# Altium schematic sheet Microphone-Boards_V1.0_GMA3526H10.SchDoc
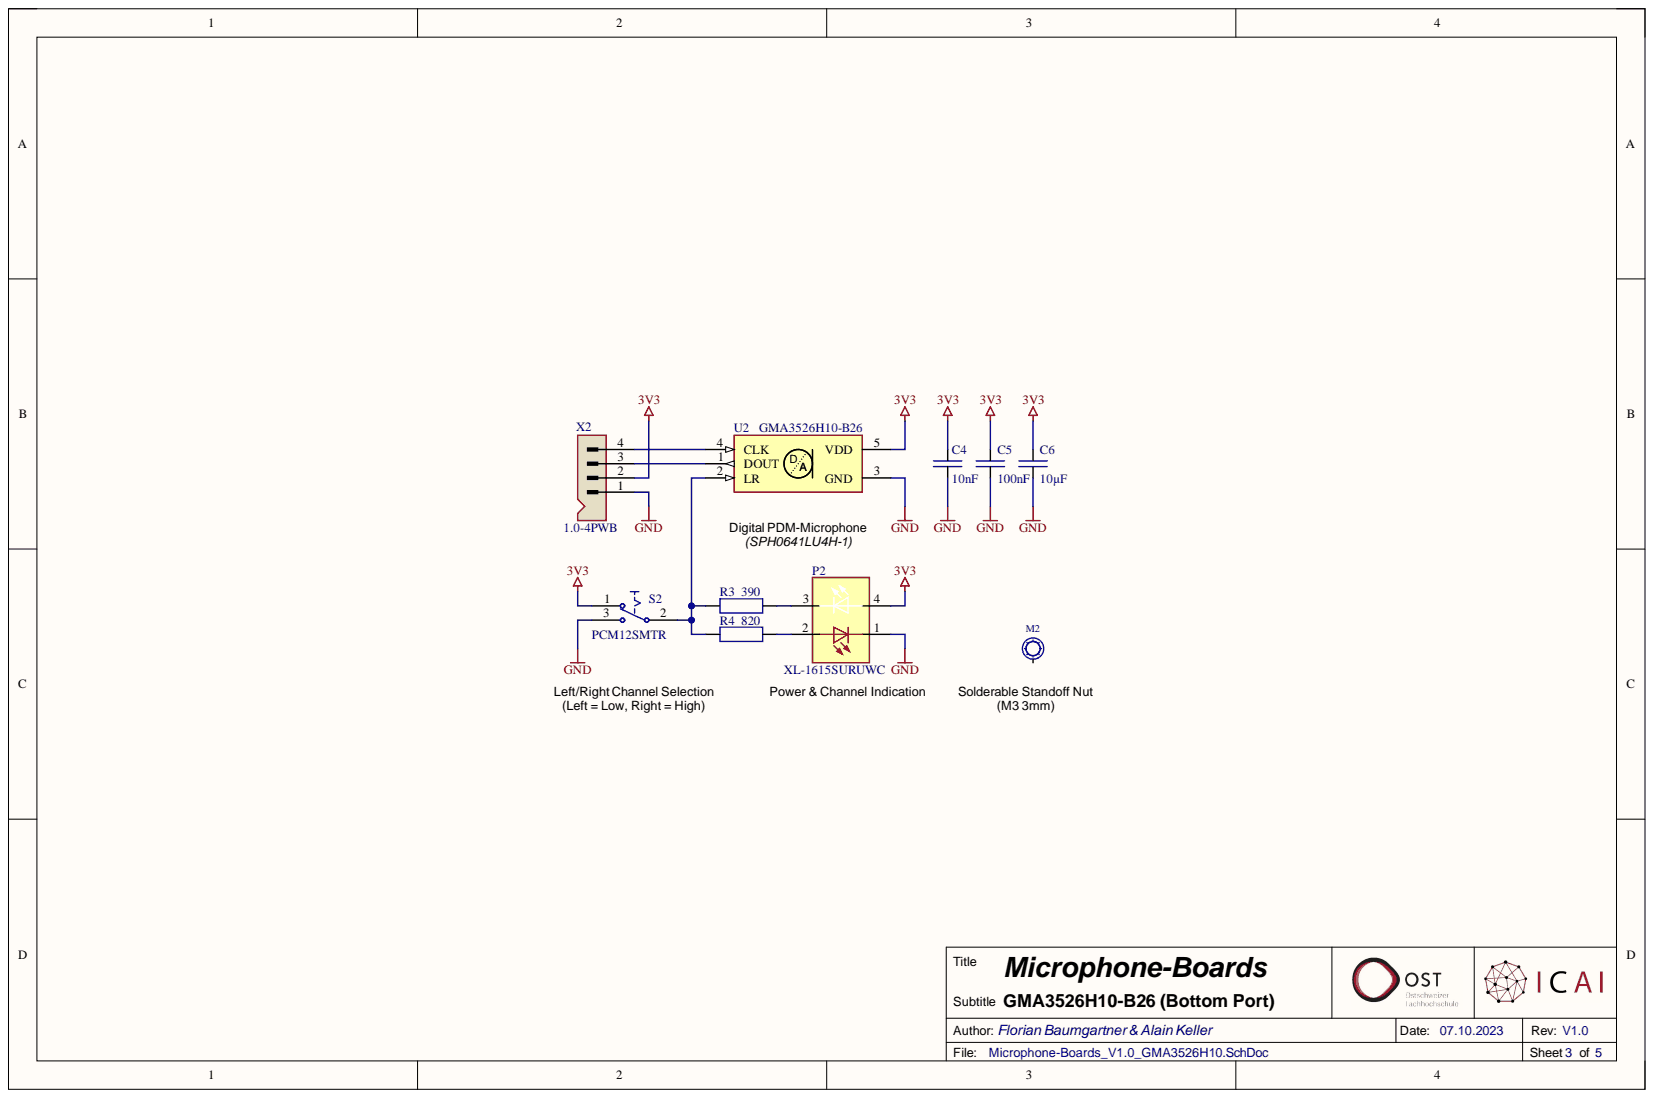
[diagram p0: Microphone-Boards_V1.0_GMA3526H10.SchDoc]

## Parts
C4  10nF  [SMD Keramikkondensator 0603]
C5  100nF  [SMD Keramikkondensator 0603]
C6  10µF  [SMD Keramikkondensator 1206]
M2  SMTSO-M3-3ET  [SMD Solder-Nut (M3 3mm)]  (value not drawn)
P2  XL-1615SURUWC  [SMD LED 1210]
R3  390  [SMD Widerstand 0603]
R4  820  [SMD Widerstand 0603]
S2  PCM12SMTR  [SMD Switch SPDT (PCM12SMTR)]
U2  GMA3526H10-B26  [SMD Microphone (MP34DT06JTR)]
X2  1.0-4PWB  [SMD Connector (SM04B-SRSS-TB)]

## Nets
3V3: C4.2 C5.2 C6.2 P2.4 S2.1 U2.5(VDD) X2.2
GND: C4.1 C5.1 C6.1 P2.1 S2.3 U2.3(GND) X2.1
NetP2_2: P2.2 R4.2
NetP2_3: P2.3 R3.2
NetR3_1: R3.1 R4.1 S2.2 U2.2(LR)
NetU2_1: U2.1(DOUT) X2.3
NetU2_4: U2.4(CLK) X2.4

Not connected: M2 1

## Library data of the parts
C4 = C-CAPACITOR: CAP-MK; Component Description: Multilayer Ceramic Capacitors; Component Value: 10nF 50V; Component Kind: Standard; Digikey: 445-5662-1-ND; Farnell: 2346966; JLC-Parts: C57112; Library Name: AudioAmp.SCHLIB; Mouser: 77-VJ0603V103ZXAPBC; Unit Price: 0.01
C5 = E6 - 100nF: SMD Ceramic Capacitor E6; Component Description: Multilayer Ceramic Capacitors MLCC; Component Value: 100nF 50V; Component Kind: Standard; ComponentLink1Description: Datasheet; ComponentLink1URL: https://datasheet.lcsc.com/lcsc/1809301912_YAGEO-CC0603KRX7R9BB104_C14663.pdf; Digikey: 445-6899-1-ND; Farnell: 2210822; JLC-Parts: C14663; Library Name: Ceramic Capacitors-E6.SchLib; Mouser: 810-CGA2B3X7R1H104K; Unit Price: 0.01
C6 = E6 - 10uF: SMD Ceramic Capacitor E6; Component Description: Multilayer Ceramic Capacitors MLCC; Component Value: 10µF 50V; Component Kind: Standard; ComponentLink1Description: Datasheet; ComponentLink1URL: https://datasheet.lcsc.com/lcsc/1810191219_Samsung-Electro-Mechanics-CL10A106KP8NNNC_C19702.pdf; Digikey: 587-3258-1-ND; Farnell: 2113070; JLC-Parts: C13585; Library Name: Ceramic Capacitors-E6.SchLib; Mouser: 963-LMK107BBJ106MALT; Unit Price: 0.01
M2 = M-Spacer_M3_3mm: Solderable Standoff Nut; Component Value: M3 3mm; Component Description: Solderable Standoff Nut; Component Kind: Standard; ComponentLink1Description: Datasheet; ComponentLink1URL: https://datasheet.lcsc.com/lcsc/2112061830_Sinhoo-SMTSO3030CTJ_C2915308.pdf; JLC-Parts: C2915308; Mouser: 153-SMTSO-M3-3ET; Unit Price: 0.05; Library Name: Velotour_2022.SCHLIB
P2 = P-XL-1615SURUWC: LED Red/White; Stückpreis: 0.02; Bauteilwert: XL-1615SURUWC; Beschreibung: LED Red/White; Component Kind: Standard; ComponentLink1Description: Datasheet; ComponentLink1URL: https://datasheet.lcsc.com/lcsc/2211030000_XINGLIGHT-XL-1615SURUWC_C965837.pdf; JLC-Parts: C965837; Library Name: Microphone-Boards.SCHLIB
R3 = R-RESISTOR: SMD-Widerstand 1/4W; Component Description: Thick Film Chip Resistor; Component Value: 390 (75V, 100mW, 1%); Component Kind: Standard; Digikey: P390HCT-ND; Farnell: 2303111; JLC-Parts: C23151; Mouser: 667-ERJ-3EKF3900V; Unit Price: 0.06; Library Name: Backplane_1.SCHLIB
R4 = R-RESISTOR: SMD-Widerstand 1/4W; Component Description: Thick Film Chip Resistor; Component Value: 820 (75V, 100mW, 1%); Component Kind: Standard; Digikey: P820HCT-ND; Farnell: 2303136; JLC-Parts: C23253; Mouser: 667-ERJ-3EKF8200V; Unit Price: 0.06; Library Name: Backplane_1.SCHLIB
S2 = S-PCM12SMTR: Miniature Slide Switch; aliExpress: 33002042977; Bauteilwert: PCM12SMTR (SPDT); Stückpreis: 0.77; Beschreibung: Miniature Slide Switch; Component Kind: Standard; Digikey: 401-2016-1-ND; Farnell: 9575146; Library Name: Custom ST-Link.SchLib; Mouser: 611-PCM12SMTR
U2 = U-GMA3526H10-B26: Digital PDM MEMS Microphone (Bottom Port); Component Description: Digital PDM MEMS Microphone (Bottom Port); Component Kind: Standard; Component Value: GMA3526H10-B26; ComponentLink1Description: Datasheet; ComponentLink1URL: https://datasheet.lcsc.com/lcsc/2004081814_INGHAi-GMA3526H10-B26_C498192.pdf; Digikey: 423-1402-1-ND; JLC-Parts: C498192; Library Name: Microphone-Boards.SCHLIB; Mouser: 721-SPH0641LU4H-1; Unit Price: 1.06
X2 = X-Stiftleiste (4-Fach): Wire To Board Connector (1x4P 1.0mm); Component Description: Wire To Board Connector (1x4P 1.0mm); Component Kind: Standard; Component Value: 1.0-4PWB; Digikey: 455-SM04B-SRSS-TBCT-ND; Farnell: 1830839; JLC-Parts: C2905006; Unit Price: 0.03; Library Name: Audio-Beamformer.SCHLIB
